# Altium schematic sheet 03)Memories&Sensors.SchDoc(03)Memories&Sensors)
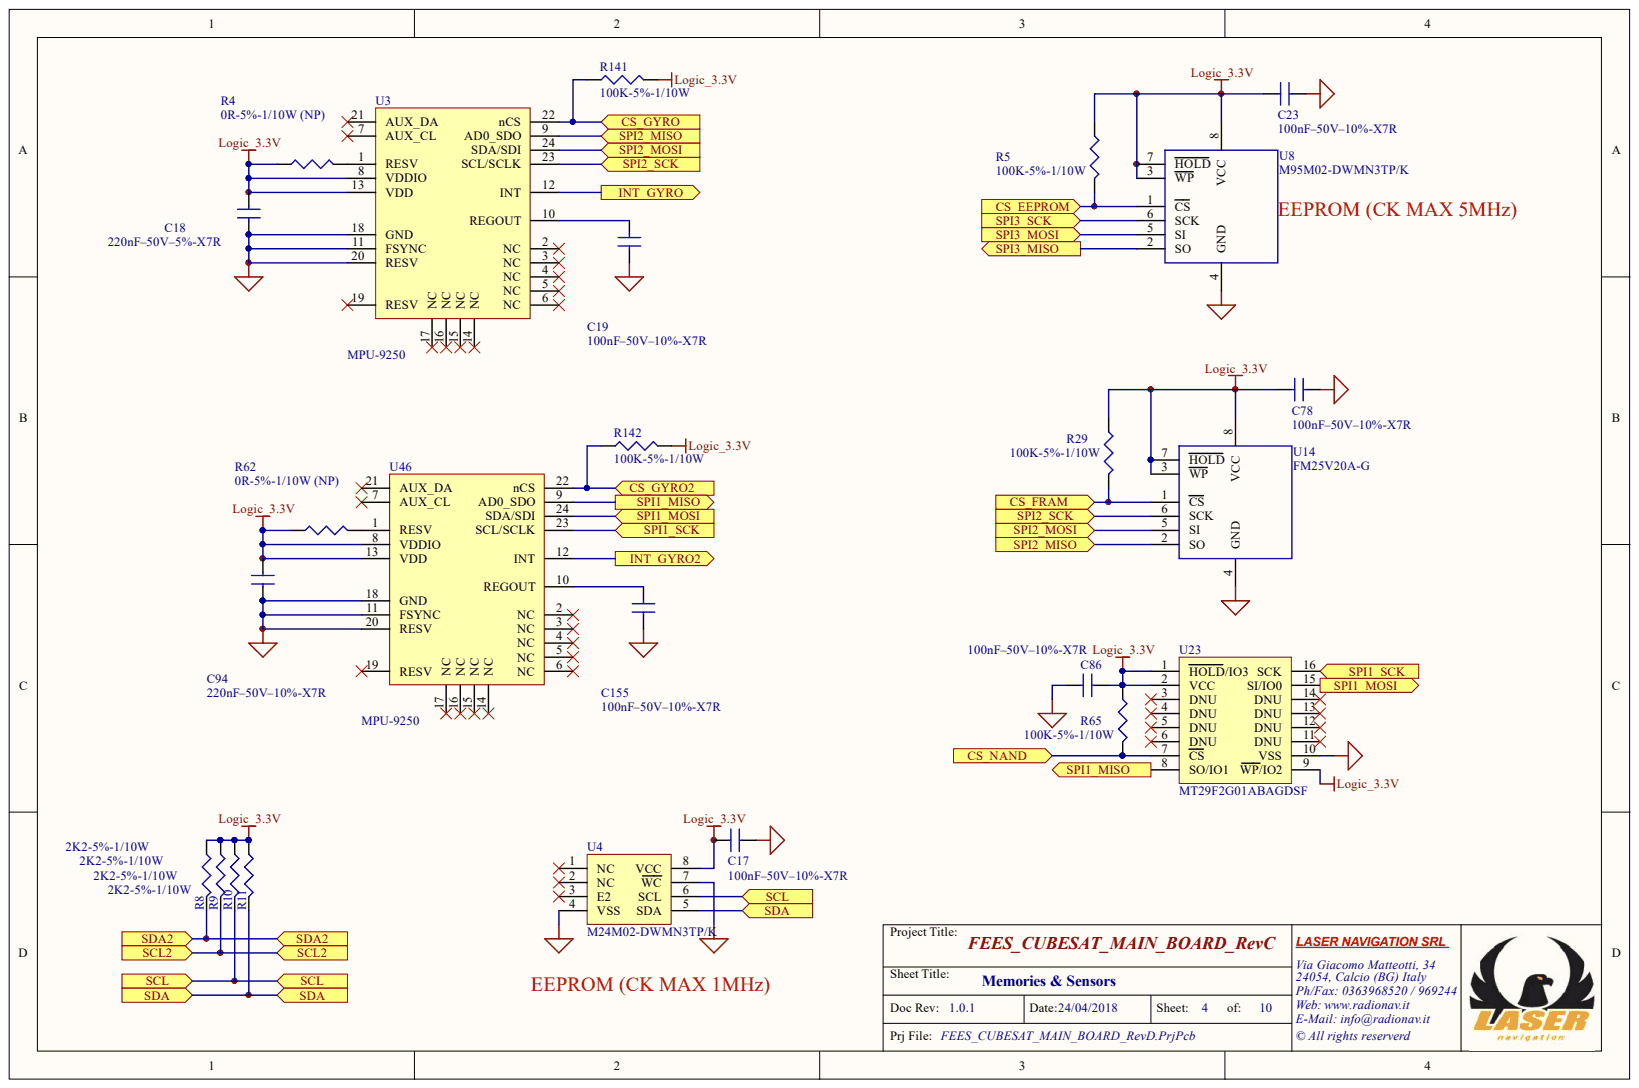
[diagram p0: 03)Memories&Sensors.SchDoc]

## Parts
C17  100nF–50V–10%-X7R  [0603_CAP]
C18  220nF–50V–5%-X7R  [0603_CAP]
C19  100nF–50V–10%-X7R  [0603_CAP]
C23  100nF–50V–10%-X7R  [0603_CAP]
C78  100nF–50V–10%-X7R  [0603_CAP]
C86  100nF–50V–10%-X7R  [0603_CAP]
C94  220nF–50V–10%-X7R  [0603_CAP]
C155  100nF–50V–10%-X7R  [0603_CAP]
R4  0R-5%-1/10W (NP)  [0603_RES]
R5  100K-5%-1/10W  [0603_RES]
R8  2K2-5%-1/10W  [0603_RES]
R9  2K2-5%-1/10W  [0603_RES]
R10  2K2-5%-1/10W  [0603_RES]
R11  2K2-5%-1/10W  [0603_RES]
R29  100K-5%-1/10W  [0603_RES]
R62  0R-5%-1/10W (NP)  [0603_RES]
R65  100K-5%-1/10W  [0603_RES]
R141  100K-5%-1/10W  [0603_RES]
R142  100K-5%-1/10W  [0603_RES]
U3  MPU-9250  [QFN-24_3x3]
U4  M24M02-DWMN3TP/K  [SO8]
U8  M95M02-DWMN3TP/K  [SO8]
U14  FM25V20A-G  [SO8M]
U23  MT29F2G01ABAGDSF  [SO16W]
U46  MPU-9250  [QFN-24_3x3]

## Ports
CS_EEPROM, CS_FRAM, CS_GYRO, CS_GYRO2, CS_NAND, INT_GYRO, INT_GYRO2, SCL, SCL2, SDA, SDA2, SPI1_MISO, SPI1_MOSI, SPI1_SCK, SPI2_MISO, SPI2_MOSI, SPI2_SCK, SPI3_MISO, SPI3_MOSI, SPI3_SCK

## Nets
GND: C17.2 C18.1 C19.1 C23.2 C78.2 C86.2 C94.1 C155.1 U3.11(FSYNC) U3.18(GND) U3.20(RESV) U4.4(VSS) U4.7(WC) U8.4(GND) U14.4(GND) U23.10(VSS) U46.11(FSYNC) U46.18(GND) U46.20(RESV)
LOGIC_3.3V: C17.1 C18.2 C23.1 C78.1 C86.1 C94.2 R4.1 R5.1 R8.1 R9.1 R10.1 R11.1 R29.1 R62.1 R65.1 R141.2 R142.2 U3.8(VDDIO) U3.13(VDD) U4.8(VCC) U8.3(WP) U8.7(HOLD) U8.8(VCC) U14.3(WP) U14.7(HOLD) U14.8(VCC) U23.1(HOLD/IO3) U23.2(VCC) U23.9(WP/IO2) U46.8(VDDIO) U46.13(VDD)
CS_EEPROM: R5.2 U8.1(CS) ; port CS_EEPROM
CS_FRAM: R29.2 U14.1(CS) ; port CS_FRAM
CS_GYRO: R141.1 U3.22(nCS) ; port CS_GYRO
CS_GYRO2: R142.1 U46.22(nCS) ; port CS_GYRO2
CS_NAND: R65.2 U23.7(CS) ; port CS_NAND
INT_GYRO: U3.12(INT) ; port INT_GYRO
INT_GYRO2: U46.12(INT) ; port INT_GYRO2
SCL: R10.2 U4.6(SCL) ; port SCL
SCL2: R9.2 ; port SCL2
SDA: R11.2 U4.5(SDA) ; port SDA
SDA2: R8.2 ; port SDA2
SPI1_MISO: U23.8(SO/IO1) U46.9(AD0_SDO) ; port SPI1_MISO
SPI1_MOSI: U23.15(SI/IO0) U46.24(SDA/SDI) ; port SPI1_MOSI
SPI1_SCK: U23.16(SCK) U46.23(SCL/SCLK) ; port SPI1_SCK
SPI2_MISO: U3.9(AD0_SDO) U14.2(SO) ; port SPI2_MISO
SPI2_MOSI: U3.24(SDA/SDI) U14.5(SI) ; port SPI2_MOSI
SPI2_SCK: U3.23(SCL/SCLK) U14.6(SCK) ; port SPI2_SCK
SPI3_MISO: U8.2(SO) ; port SPI3_MISO
SPI3_MOSI: U8.5(SI) ; port SPI3_MOSI
SPI3_SCK: U8.6(SCK) ; port SPI3_SCK
NetC19_2: C19.2 U3.10(REGOUT)
NetC155_2: C155.2 U46.10(REGOUT)
NetR4_2: R4.2 U3.1(RESV)
NetR62_2: R62.2 U46.1(RESV)

Not connected: U3 2(NC) 3(NC) 4(NC) 5(NC) 6(NC) 7(AUX_CL) 14(NC) 15(NC) 16(NC) 17(NC) 19(RESV) 21(AUX_DA); U4 1(NC) 2(NC) 3(E2); U23 3(DNU) 4(DNU) 5(DNU) 6(DNU) 11(DNU) 12(DNU) 13(DNU) 14(DNU); U46 2(NC) 3(NC) 4(NC) 5(NC) 6(NC) 7(AUX_CL) 14(NC) 15(NC) 16(NC) 17(NC) 19(RESV) 21(AUX_DA)

## Library data of the parts
C17 C19 C23 C78 C86 C94 C155 = CAP: Non polarized capacitor; Component Kind: Standard; Library Name: User Sch Library.SchLib
C18 = CAP: Non polarized capacitor; 1.Part Number: CC0805KRX7R7BB224; 2.Manufacturer: Yageo; 3.Description: CAP CER 0.22UF 16V X7R 0805; 5.Mounting: Y; 4.Supplier: Digikey 311-3390-1-ND; Component Kind: Standard; Library Name: User Sch Library.SchLib
R4 R62 = R: 5.Mounting: not mounted; Component Kind: Standard; Library Name: User Sch Library.SchLib
R5 R8-R11 R29 R65 R141 R142 = R: Component Kind: Standard; Library Name: User Sch Library.SchLib
U3 U46 = MPU-6500: 3axis Gyro + Accelerom + MAG; 2.Manufacturer: Invensense; 3.Description: GYRO/ACCELEROMETER 6AXIS 24QFN; 5.Mounting: Y; 1.Part Number: MPU-9250; 4.Supplier: http://www.cdiweb.com; Component Kind: Standard; Library Name: User Sch Library.SchLib
U4 = M24M02: EEPROM 2MBIT; 2.Manufacturer: STMicroelectronics; 3.Description: IC EEPROM 2MBIT 1MHZ 8SO; 5.Mounting: Y; 1.Part Number: M24M02-DWMN3TP/K; 4.Supplier: Digikey 497-16238-1-ND; Component Kind: Standard; Library Name: User Sch Library.SchLib
U8 = M95M02: EEPROM 2MBIT; 2.Manufacturer: STMicroelectronics; 3.Description: IC EEPROM 2MBIT 10MHZ 8SOIC; 5.Mounting: Y; 1.Part Number: M95M02-DWMN3TP/K; 4.Supplier: Digikey 497-15597-1-ND; Component Kind: Standard; Library Name: User Sch Library.SchLib
U14 = FM25VXX: FRAM SPI; 2.Manufacturer: Cypress Semiconductor Corp; 3.Description: IC FRAM 2MBIT 40MHZ 8SOIC; 5.Mounting: Y; 1.Part Number: FM25V20A-G; 4.Supplier: Digikey FM25V20A-G-ND; Component Kind: Standard; Library Name: User Sch Library.SchLib
U23 = MT29F2G01ABAGDSF: NAND FLASH; 2.Manufacturer: Micron Technology Inc.; 3.Description: IC FLASH 2GBIT 16SO; 5.Mounting: Y; 1.Part Number: MT29F2G01ABAGDSF; 4.Supplier: Digikey 557-1663-1-ND; Component Kind: Standard; Library Name: User Sch Library.SchLib
